# Altium schematic sheet CONNECTIVITY.SchDoc(CONNECTIVITY)
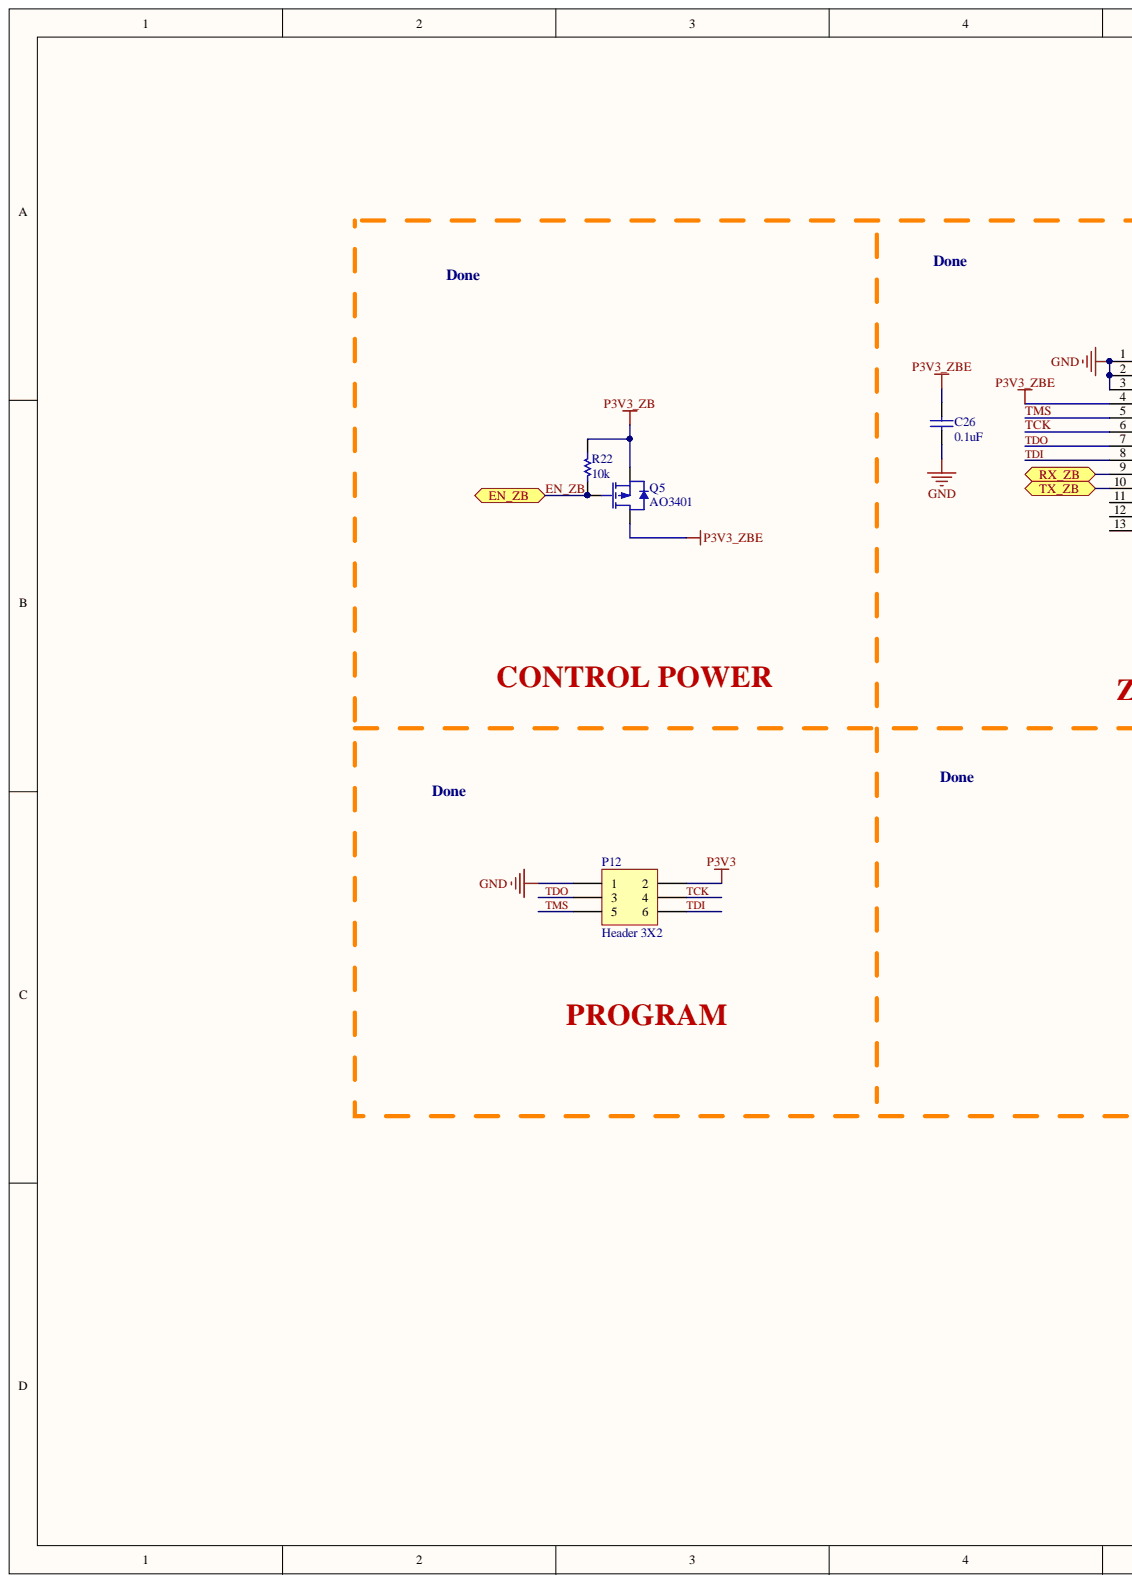
[diagram p0: CONNECTIVITY.SchDoc, left]
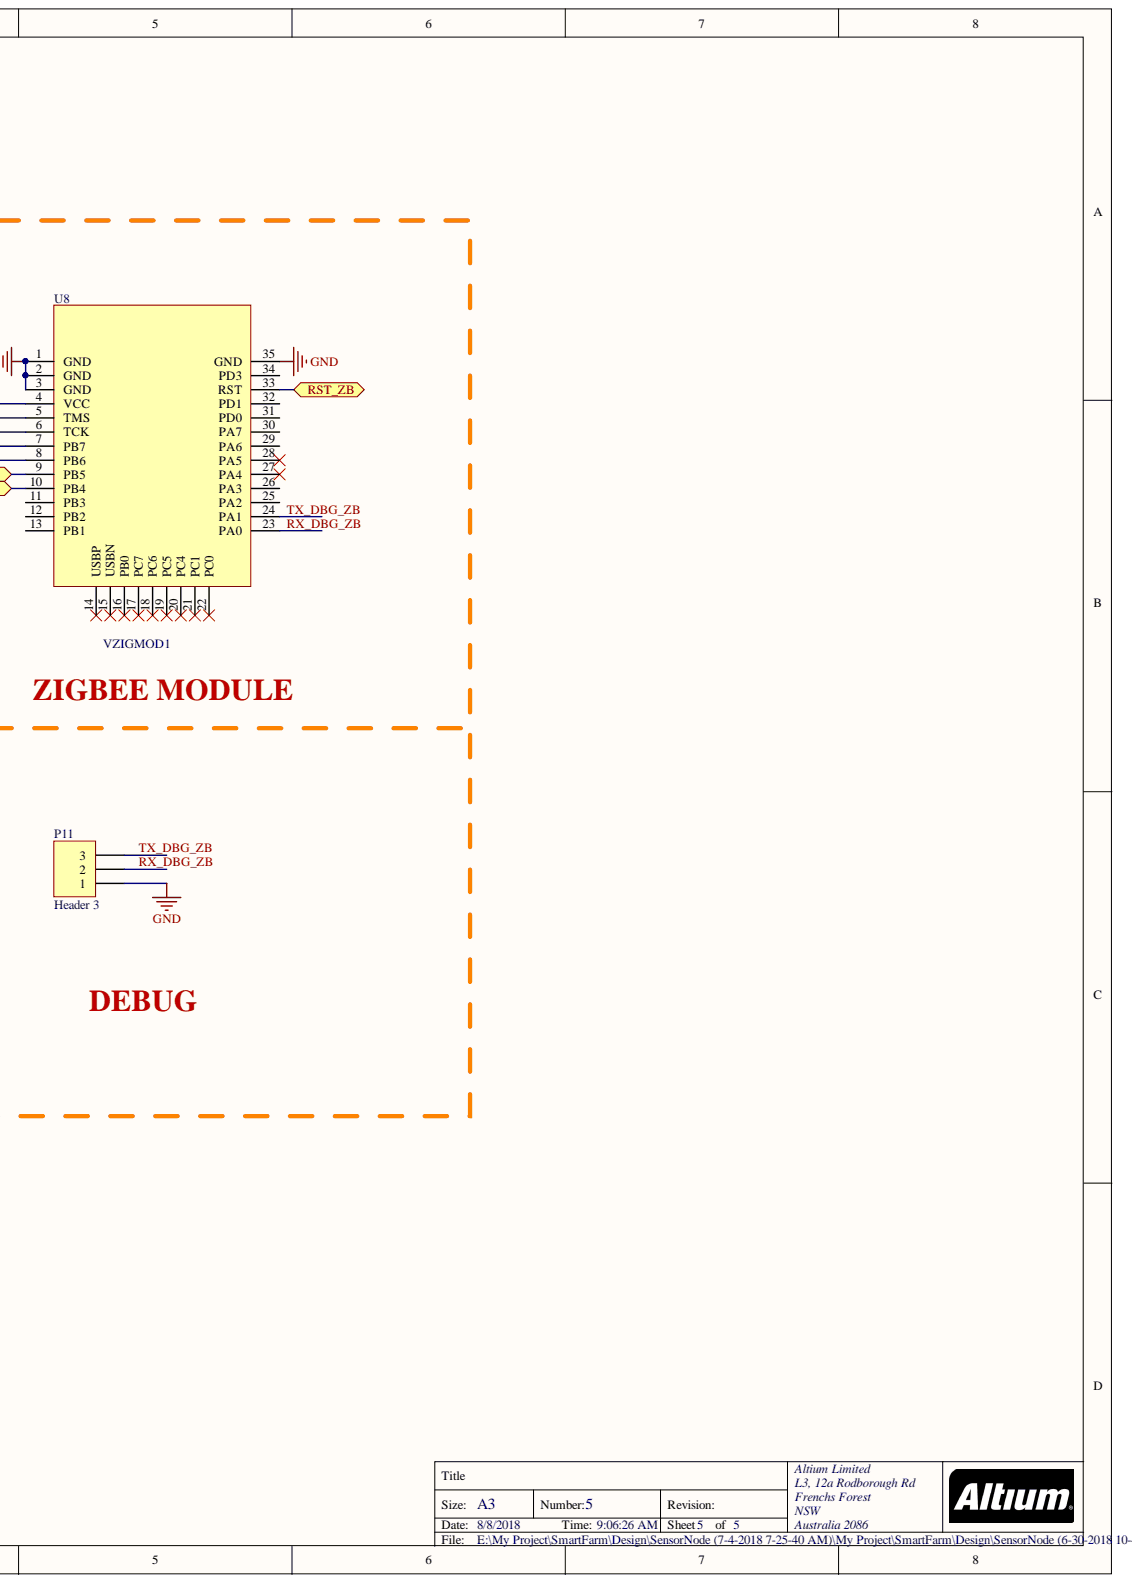
[diagram p1: CONNECTIVITY.SchDoc, right]

## Parts
C26  0.1uF  [C0402M]
P11  Header 3  [HDR1X3]
P12  Header 3X2  [HDR2X3]
Q5  AO3401  [SOT23-3N]
R22  10k  [R0603M]
U8  VZIGMOD1  [VZIGMOD2]

## Ports
EN_ZB, RST_ZB, RX_ZB, TX_ZB

## Nets
GND: C26.1 P11.1 P12.1 U8.1(GND) U8.2(GND) U8.3(GND) U8.35(GND)
P3V3: P12.2
P3V3_ZB: Q5.2 R22.2
P3V3_ZBE: C26.2 Q5.3 U8.4(VCC)
EN_ZB: Q5.1 R22.1 ; port EN_ZB
RST_ZB: U8.33(RST) ; port RST_ZB
RX_DBG_ZB: P11.2 U8.23(PA0)
RX_ZB: U8.9(PB5) ; port RX_ZB
TCK: P12.4 U8.6(TCK)
TDI: P12.6 U8.8(PB6)
TDO: P12.3 U8.7(PB7)
TMS: P12.5 U8.5(TMS)
TX_DBG_ZB: P11.3 U8.24(PA1)
TX_ZB: U8.10(PB4) ; port TX_ZB

Not connected: U8 11(PB3) 12(PB2) 13(PB1) 14(USBP) 15(USBN) 16(PB0) 17(PC7) 18(PC6) 19(PC5) 20(PC4) 21(PC1) 22(PC0) 25(PA2) 26(PA3) 27(PA4) 28(PA5) 29(PA6) 30(PA7) 31(PD0) 32(PD1) 34(PD3)

## Library data of the parts
C26 = CAP0.1u0402: CAP CER 0.1UF 16V X7R 0402; Manufacturer Part Number: CL05B104KO5NNNC; Manufacturer: Samsung Electro-Mechanics; Tolerance: ±10%; Notification: Current market demand for these product types have resulted in fluctuating and extending lead times. Lead times may differ.; Module: Connectivity; Voltage - Rated: 16V; Thickness (Max): 0.022" (0.55mm); Temperature Coefficient: X7R; Supplier Part Number 1: 1276-1001-6-ND; Supplier 1: Digi-Key; Stock 1: 100000; Size / Dimension: 0.039" L x 0.020" W (1.00mm x 0.50mm); RoHS: RoHS Compliant; Ratings: -; Pricing 1: 1=0.1, 10=0.03, 100=0.0134, 500=0.00958, 1000=0.00753, 2500=0.00684, 5000=0.0063 (USD); Part Status: Active; Packaging: Digi-Reel®; Package / Case: 0402 (1005 Metric); Operating Temperature: -55°C ~ 125°C; Mounting Type: Surface Mount, MLCC; Lead Style: -; Lead Spacing: -; Height - Seated (Max): -; Features: -; ComponentLink1URL: http://www.samsungsem.com/kr/support/product-search/mlcc/CL05B104KO5NNNC.jsp; ComponentLink1Description: http://www.samsungsem.com/kr/support/product-search/mlcc/CL05B104KO5NNNC.jsp; Component Kind: Standard; Category: Capacitors; Applications: General Purpose; Library Name: BinhLib.IntLib
P11 = Header 3: Header, 3-Pin; Signal Integrity: Connector; LatestRevisionNote: Re-released for DXP Platform.; LatestRevisionDate: 17-Jul-2002; Component Kind: Standard; Publisher: Altium Limited; Library Name: BinhLib.IntLib
P12 = Header 3X2: Header, 3-Pin, Dual row; Signal Integrity: Connector; LatestRevisionNote: Re-released for DXP Platform.; LatestRevisionDate: 17-Jul-2002; Component Kind: Standard; Publisher: Altium Limited; Library Name: BinhLib.IntLib
Q5 = MOSFET-P1: P-Channel MOSFET; Component Kind: Standard; Library Name: BinhLib.IntLib
R22 = RES10k0603: RES SMD 10K OHM 1% 1/10W 0603; Manufacturer Part Number: ERJ-3EKF1002V; Manufacturer: Panasonic Electronic Components; Temperature Coefficient: ±100ppm/°C; Power (Watts): 0.1W, 1/10W; Tolerance: ±1%; Supplier Part Number 1: P10.0KHDKR-ND; Supplier Device Package: 0603; Supplier 1: Digi-Key; Stock 1: 0; Size / Dimension: 0.063" L x 0.031" W (1.60mm x 0.80mm); RoHS: RoHS Compliant; Resistance (Ohms): 10k; Pricing 1: 1=0.1, 10=0.021, 100=0.0087, 1000=0.00389, 2500=0.00338 (USD); Part Status: Active; Packaging: Digi-Reel®; Package / Case: 0603 (1608 Metric); Operating Temperature: -55°C ~ 155°C; Height - Seated (Max): 0.022" (0.55mm); Features: Automotive AEC-Q200; Failure Rate: -; Composition: Thick Film; ComponentLink1URL: https://industrial.panasonic.com/cdbs/www-data/pdf/RDA0000/AOA0000C298.pdf; ComponentLink1Description: https://industrial.panasonic.com/cdbs/www-data/pdf/RDA0000/AOA0000C298.pdf; Component Kind: Standard; Category: Resistors; Library Name: BinhLib.IntLib
U8 = VZIGMOD2: VNPT ZIGBEE MODULE V1 - No-PA; Utilized IC / Part: CC2538; Manufacturer: VNPT-Technology; Voltage - Supply: 1.85 V ~ 3.8 V; Serial Interfaces: I²C, SPI, UART; Sensitivity: -99dBm; Power - Output: 7dBm; Module: Connectivity; Frequency: 2.4GHz; Data Rate: 250kbps; Catgory: RF/IF and RFID; Antenna Type: Integrated, PCB, External; Package / Case: 33-SMD Module; Operating Temperature: -40°C ~ 85°C; Mounting Type: Surface Mount; Manufacturer Part Number: VZIGMOD1; Library Name: SensorNode.SchLib; Component Kind: Standard
